# Altium schematic sheet Sheet2.SchDoc
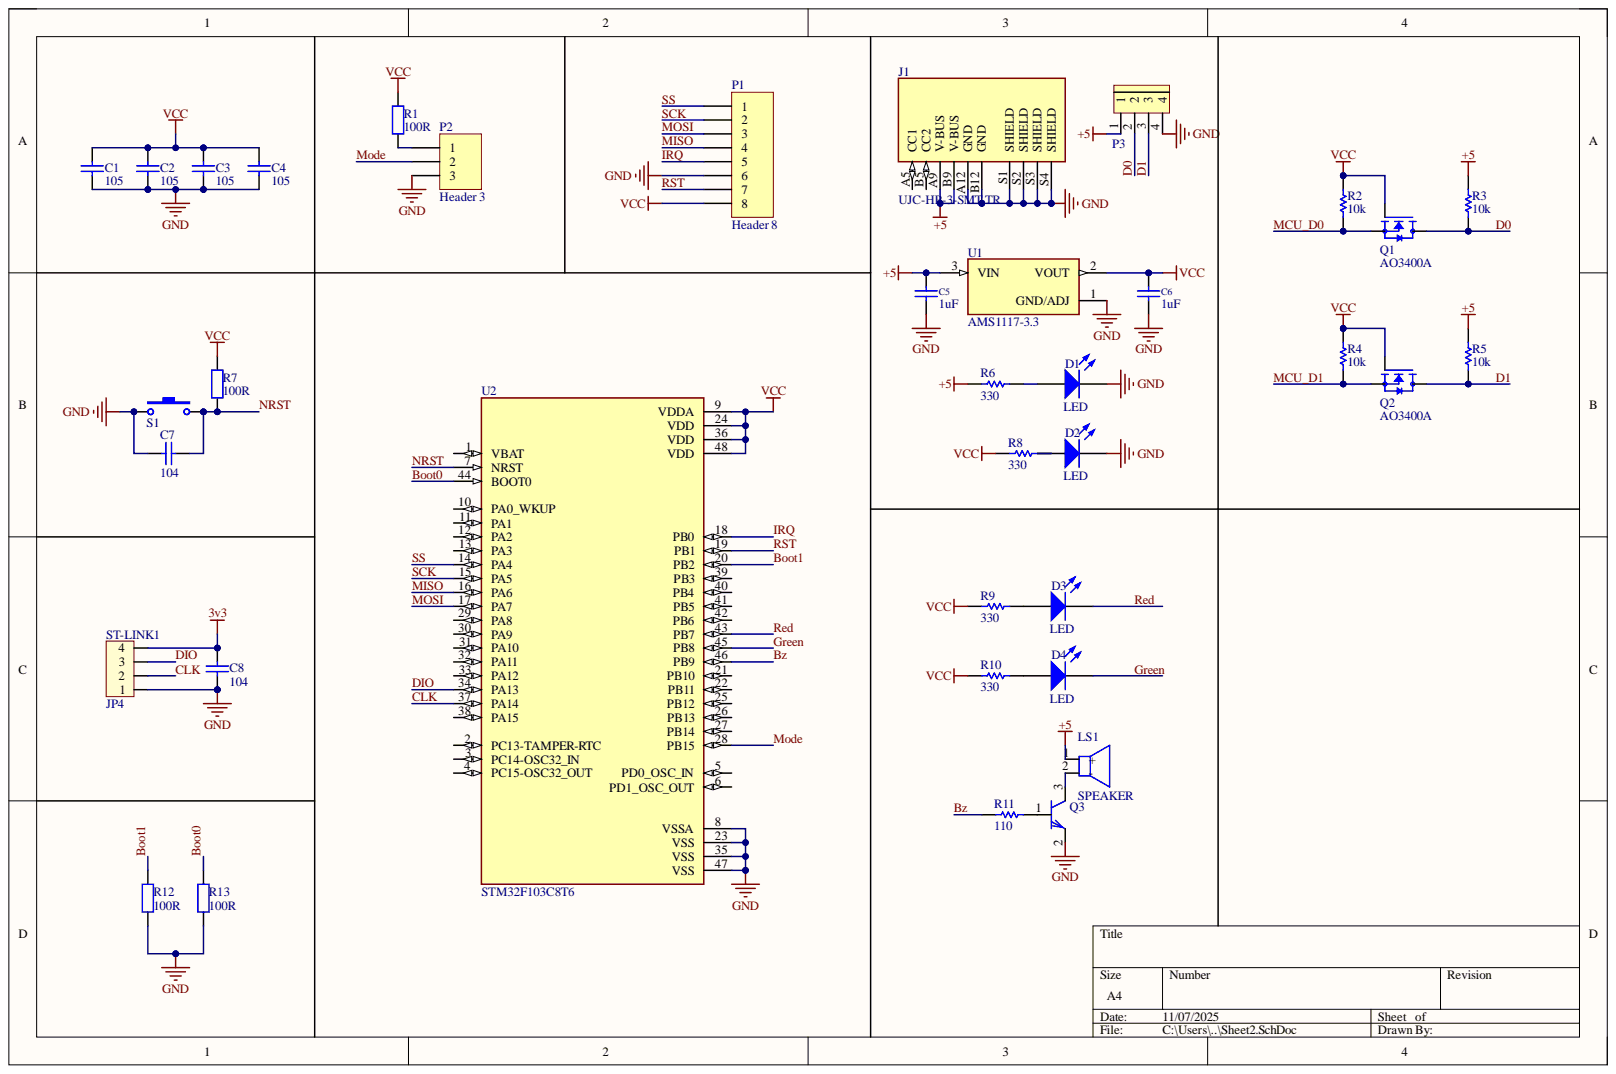
[diagram p0: Sheet2.SchDoc]

## Parts
C1  value 105  [C-NP-0805]  (hidden comment: Cap Non-pol)
C2  value 105  [C-NP-0805]  (hidden comment: Cap Non-pol)
C3  value 105  [C-NP-0805]  (hidden comment: Cap Non-pol)
C4  value 105  [C-NP-0805]  (hidden comment: Cap Non-pol)
C5  1uF  [C2012-0805]
C6  1uF  [C2012-0805]
C7  value 104  [C-NP-0805]  (hidden comment: Cap Non-pol)
C8  value 104  [C-NP-0805]  (hidden comment: Cap Non-pol)
D1  LED  [LED-0805 RED]
D2  LED  [LED-0805 RED]
D3  LED  [LED-0 3mm RED]
D4  LED  [LED-0 3mm RED]
J1  UJC-HP-3-SMT-TR  [CUI_UJC-HP-3-SMT-TR]
LS1  SPEAKER  [speaker]
P1  Header 8  [Header 8]
P2  Header 3  [Header 3]
P3  BIG Header 4  [BIG_Header 4]  (value not drawn)
Q1  AO3400A  [SOT95P280X125-3N]
Q2  AO3400A  [SOT95P280X125-3N]
Q3  C1815  [SOT23 [C1815]]  (value not drawn)
R1  value 100R  [DIEN TRO 0805]  (hidden comment: RES_SMD_0805)
R2  value 10k  [R-0805]  (hidden comment: RESISTOR)
R3  value 10k  [R-0805]  (hidden comment: RESISTOR)
R4  value 10k  [R-0805]  (hidden comment: RESISTOR)
R5  value 10k  [R-0805]  (hidden comment: RESISTOR)
R6  value 330  [R-0805]  (hidden comment: RESISTOR)
R7  value 100R  [DIEN TRO 0805]  (hidden comment: RES_SMD_0805)
R8  value 330  [R-0805]  (hidden comment: RESISTOR)
R9  value 330  [R-0805]  (hidden comment: RESISTOR)
R10  value 330  [R-0805]  (hidden comment: RESISTOR)
R11  value 110  [R-0805]  (hidden comment: RESISTOR)
R12  value 100R  [DIEN TRO 0805]  (hidden comment: RES_SMD_0805)
R13  value 100R  [DIEN TRO 0805]  (hidden comment: RES_SMD_0805)
S1  SW-SMD  [SW-SMD]  (value not drawn)
ST-LINK1  JP4  [CON4]
U1  AMS1117-3.3  [SOT229P700X180-4N]
U2  STM32F103C8T6  [QFP50P900X900X160-48N]

## Nets
3v3: C8.1 ST-LINK1.4
+5: C5.2 J1.A9(V-BUS) J1.B9(V-BUS) LS1.1 P3.1 R3.2 R5.2 R6.1 U1.3
GND: C1.1 C2.1 C3.1 C4.1 C5.1 C6.2 C7.2 C8.2 D1.2 D2.2 J1.A12(GND) J1.B12(GND) J1.S1(SHIELD) J1.S2(SHIELD) J1.S3(SHIELD) J1.S4(SHIELD) P1.6 P2.3 P3.4 Q3.2 R12.2 R13.1 S1.1 ST-LINK1.1 U1.1 U2.8(VSSA) U2.23(VSS) U2.35(VSS) U2.47(VSS)
VCC: C1.2 C2.2 C3.2 C4.2 C6.1 P1.8 Q1.1 Q2.1 R1.2 R2.2 R4.2 R7.2 R8.1 R9.1 R10.1 U1.2 U2.9(VDDA) U2.24(VDD) U2.36(VDD) U2.48(VDD)
Boot0: R13.2 U2.44(BOOT0)
Boot1: R12.1 U2.20(PB2)
Bz: R11.1 U2.46(PB9)
CLK: ST-LINK1.2 U2.37(PA14)
D0: P3.2 Q1.3 R3.1
D1: P3.3 Q2.3 R5.1
DIO: ST-LINK1.3 U2.34(PA13)
Green: D4.2 U2.45(PB8)
IRQ: P1.5 U2.18(PB0)
MCU_D0: Q1.2 R2.1
MCU_D1: Q2.2 R4.1
MISO: P1.4 U2.16(PA6)
Mode: P2.2 U2.28(PB15)
MOSI: P1.3 U2.17(PA7)
NRST: C7.1 R7.1 S1.2 U2.7(NRST)
Red: D3.2 U2.43(PB7)
RST: P1.7 U2.19(PB1)
SCK: P1.2 U2.15(PA5)
SS: P1.1 U2.14(PA4)
NetD1_1: D1.1 R6.2
NetD2_1: D2.1 R8.2
NetD3_1: D3.1 R9.2
NetD4_1: D4.1 R10.2
NetLS1_2: LS1.2 Q3.3
NetP2_1: P2.1 R1.1
NetQ3_1: Q3.1 R11.2

Not connected: J1 A5(CC1) B5(CC2); U2 1(VBAT) 2(PC13-TAMPER-RTC) 3(PC14-OSC32_IN) 4(PC15-OSC32_OUT) 5(PD0_OSC_IN) 6(PD1_OSC_OUT) 10(PA0_WKUP) 11(PA1) 12(PA2) 13(PA3) 21(PB10) 22(PB11) 25(PB12) 26(PB13) 27(PB14) 29(PA8) 30(PA9) 31(PA10) 32(PA11) 33(PA12) 38(PA15) 39(PB3) 40(PB4) 41(PB5) 42(PB6)

## Library data of the parts
C1-C4 C7 C8 = Cap Non-pol: Non-polarized Capacitor; Component Kind: Standard; LatestRevisionDate: 17-Jul-2002; LatestRevisionNote: Re-released for DXP Platform.; PackageReference: RAD-0.3; Published: 8-Jun-2000; Library Name: Kiet.IntLib; Publisher: Altium Limited
C5 C6 = Capacitor: AEMS Code: 2100341; Vendor: Digikey; Volt: 16V; Component Kind: Standard; ComponentLink1Description: Digikey Part Link; ComponentLink2Description: Open Datasheet; Description: Capacitor; PCB3D: SMT_0603; Published: 13-April-2009; Signal Integrity: Guessed Model; Value: 1uF; ComponentLink2URL: H:\LIBRARY\LIBRARY.Datasheet\; Library Name: Kiet.IntLib; Publisher: Hoang Nguyen
D1-D4 = LED: Typical LED; Component Kind: Standard; LatestRevisionDate: 08-Jul-2005; LatestRevisionNote: Mech Layer 1 removed.; PackageReference: LED-1; Published: 8-Jun-2000; Library Name: Kiet.IntLib; Publisher: Altium Limited
LS1 = SPEAKER: Vendor: Digikey; Component Kind: Standard; ComponentLink1Description: Digikey Part Link; ComponentLink2Description: Open Datasheet; Published: 13-April-2009; ComponentLink2URL: H:\LIBRARY\LIBRARY.Datasheet\; Library Name: Kiet.IntLib; Publisher: Hoang Nguyen
P1 = Header 8: Header, 8-Pin; Component Kind: Standard; LatestRevisionDate: 17-Jul-2002; LatestRevisionNote: Re-released for DXP Platform.; Library Name: Kiet.IntLib; Publisher: Altium Limited
P2 = Header 3: Header, 3-Pin; Component Kind: Standard; LatestRevisionDate: 17-Jul-2002; LatestRevisionNote: Re-released for DXP Platform.; Library Name: Kiet.IntLib; Publisher: Altium Limited
P3 = BIG_Header 4: Component Kind: Standard; Library Name: Kiet.IntLib
Q1 Q2 = AO3400A: Trans MOSFET N-CH 30V 5.7A 3-Pin SOT-23; Availability: Unavailable; Component Kind: Standard; Library Name: AO3400A.IntLib; MP: AO3400A; Package: None; Price: None
Q3 = C1815: Q2SC1815; PSpiceTemplate: Q^@REFDES %c %b %e @MODEL; Vendor: Digikey; COMPONENT: 2SC1815; Component Kind: Standard; ComponentLink1Description: Digikey Part Link; ComponentLink2Description: Open Datasheet; Price: 500VND; Published: 13-April-2009; Simulation: Q2SC1815; ComponentLink2URL: H:\LIBRARY\LIBRARY.Datasheet\; Library Name: Kiet.IntLib; Publisher: Hoang Nguyen
R1 R7 R12 R13 = RES_SMD_0805: RES_0805; Component Kind: Standard; LatestRevisionDate: 17-Jul-2002; LatestRevisionNote: Re-released for DXP Platform.; PackageReference: AXIAL-0.4; Published: 8-Jun-2000; Signal Integrity: Res; Simulation: RESISTOR; Library Name: Kiet.IntLib; Publisher: Altium Limited
S1 = SW-SMD: Switch; Component Kind: Standard; LatestRevisionDate: 17-Jul-2002; LatestRevisionNote: Re-released for DXP Platform.; PackageReference: SPST-2; Published: 24-Mar-1999; Library Name: Kiet.IntLib; Publisher: Altium Limited
ST-LINK1 = Con4: Connector 4; Component Kind: Standard; Value: Connector 4; Library Name: Kiet.IntLib
U1 = AMS1117-3.3: Sot223/Pkg 1-Amp 3.3-Volt Low Drop Out Voltage Regulatator; MF: Advanced Monolithic Systems; Availability: Not in stock; Check_prices: https://www.snapeda.com/parts/AMS1117-3.3/Advanced+Monolithic+Systems/view-part/?ref=eda; Component Kind: Standard; Library Name: AMS1117-3.3.IntLib; MP: AMS1117-3.3; Package: SOT-223 Seeed Technology; Price: None; SnapEDA_Link: https://www.snapeda.com/parts/AMS1117-3.3/Advanced+Monolithic+Systems/view-part/?ref=snap
U2 = STM32F103C8T6: ARM® Cortex®-M3 STM32F1 Microcontroller IC 32-Bit 72MHz 64KB (64K x 8) FLASH 48-LQFP (7x7); MF: STMicroelectronics; Check_prices: https://www.snapeda.com/parts/STM32F103C8T6/STMicroelectronics/view-part/?ref=eda; Component Kind: Standard; Library Name: STM32F103C8T6.IntLib; MP: STM32F103C8T6; Package: LQFP-48 STMicroelectronics; SnapEDA_Link: https://www.snapeda.com/parts/STM32F103C8T6/STMicroelectronics/view-part/?ref=snap
J1 = UJC-HP-3-SMT-TR: Availability: Unavailable; Component Kind: Standard; Library Name: UJC-HP-3-SMT-TR.IntLib; MP: UJC-HP-3-SMT-TR; Package: None; Price: None
R2-R6 R8-R11 = RESISTOR: Component Kind: Standard; Description: Resistor; LatestRevisionDate: 17-Jul-2002; LatestRevisionNote: Re-released for DXP Platform.; PackageReference: AXIAL-0.3; Published: 8-Jun-2000; Library Name: Kiet.IntLib; Publisher: Altium Limited
